# Altium schematic sheet toaster.SchDoc
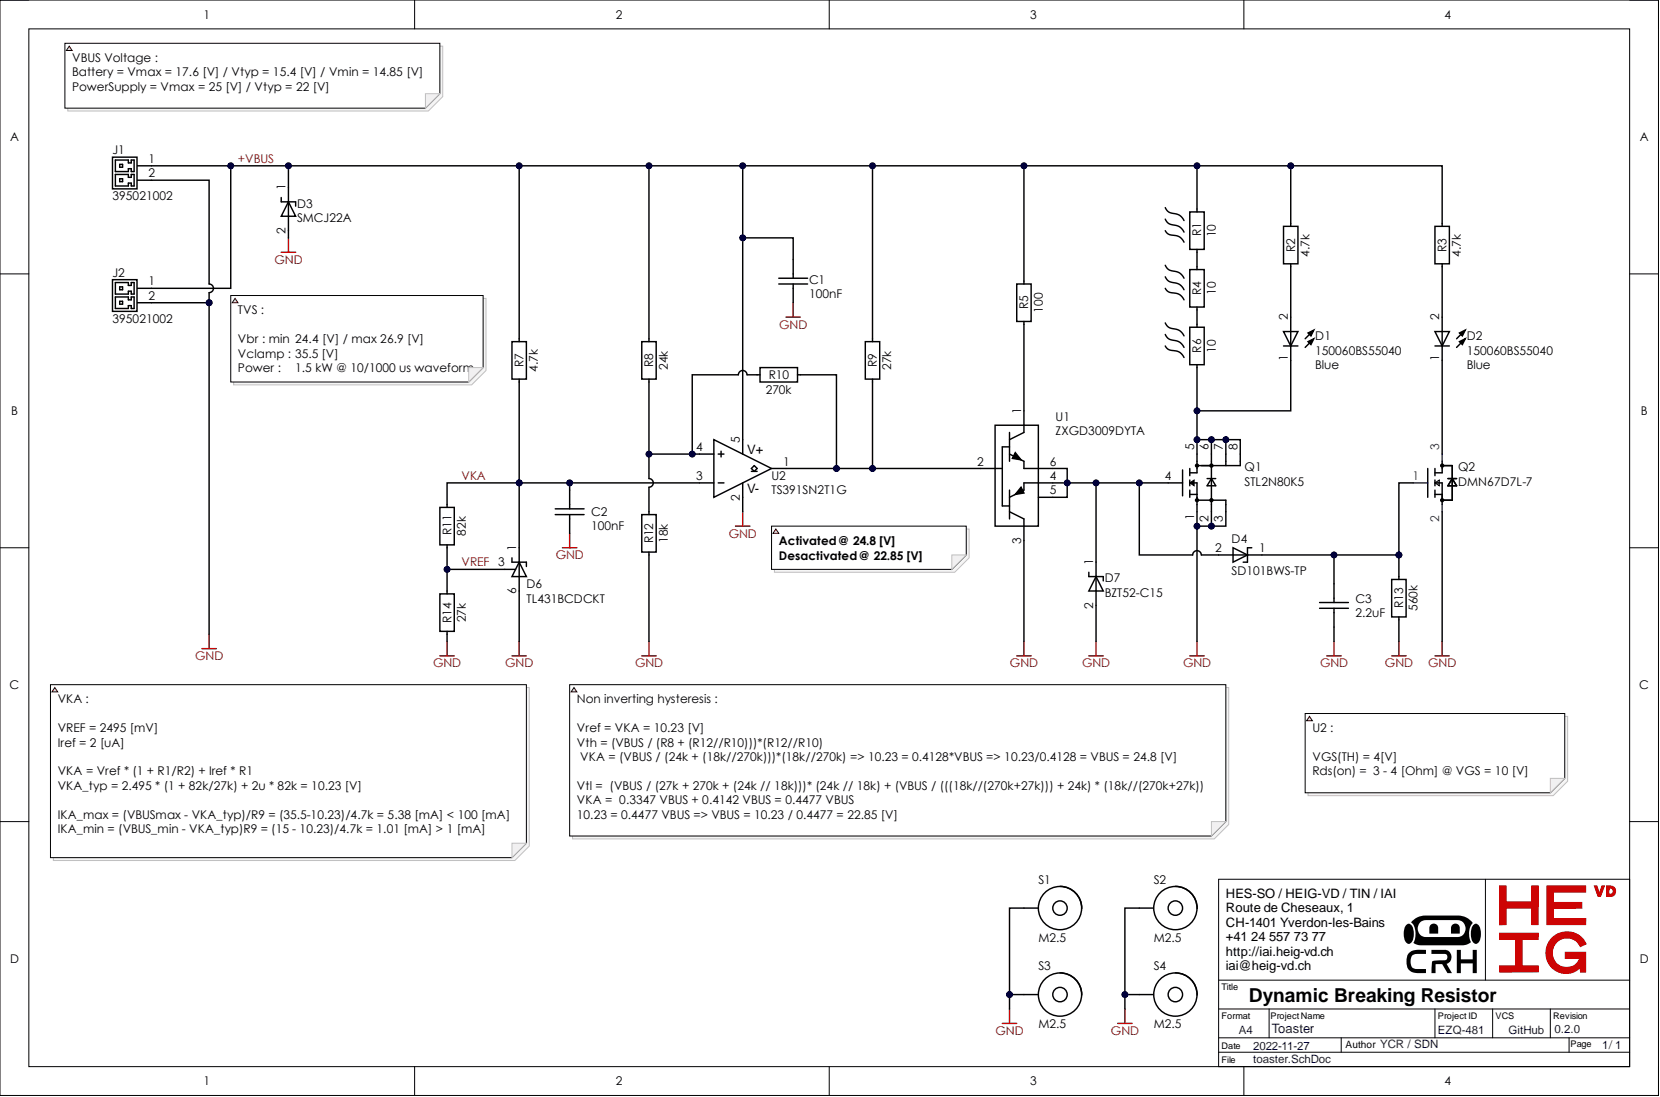
[diagram p0: toaster.SchDoc]

## Parts
C1  100nF  [CAPC1608X08N]
C2  100nF  [CAPC1608X08N]
C3  2.2uF  [CAPC1608X08N]
D1  150060BS55040  Color: Blue  [DIOC1608X05N]
D2  150060BS55040  Color: Blue  [DIOC1608X05N]
D3  SMCJ22A  [DIOM6959X26N]
D4  SD101BWS-TP  [SODFL260X115-2N]
D6  TL431BCDCKT  [SC70-6]
D7  BZT52-C15  [SODFL375X135-2N]
J1  395021002  [CONN2/PHOENIX/MC 1.5-2-G-3.5-BLACK]
J2  395021002  [CONN2/PHOENIX/MC 1.5-2-G-3.5-BLACK]
Q1  STL2N80K5  [PG-TDSON-8_INF]
Q2  DMN67D7L-7  [SOT23-3]
R1  10  [RW5S0FA]
R2  4.7k  [RESC1608X06N]
R3  4.7k  [RESC1608X06N]
R4  10  [RW5S0FA]
R5  100  [RESC1608X06N]
R6  10  [RW5S0FA]
R7  4.7k  [RESC1608X06N]
R8  24k  [RESC1608X06N]
R9  27k  [RESC1608X06N]
R10  270k  [RESC1608X06N]
R11  82k  [RESC1608X06N]
R12  18k  [RESC1608X06N]
R13  560k  [RESC1608X06N]
R14  27k  [RESC1608X06N]
S1  M2.5  [HW/HOLE/M2.5/VIA]  (no BOM)
S2  M2.5  [HW/HOLE/M2.5/VIA]  (no BOM)
S3  M2.5  [HW/HOLE/M2.5/VIA]  (no BOM)
S4  M2.5  [HW/HOLE/M2.5/VIA]  (no BOM)
U1  ZXGD3009DYTA  [SC70-6]
U2  TS391SN2T1G  [SOT23-5]

## Nets
GND: C1.2 C2.2 C3.2 D3.2 D6.6 D7.2 J1.2 J2.2 Q1.1 Q1.2 Q1.3 Q2.2 R12.1 R13.1 R14.1 S1.1 S2.1 S3.1 S4.1 U1.3 U2.2
+VBUS: C1.1 D3.1 J1.1 J2.1 R1.2 R2.2 R3.2 R5.2 R7.2 R8.2 R9.2 U2.5
VKA: C2.1 D6.1 R7.1 R11.2 U2.3
VREF: D6.3 R11.1 R14.2
NetC3_1: C3.1 D4.1 Q2.1 R13.2
NetD1_1: D1.1 Q1.5 Q1.6 Q1.7 Q1.8 R6.1
NetD1_2: D1.2 R2.1
NetD2_1: D2.1 Q2.3
NetD2_2: D2.2 R3.1
NetD4_2: D4.2 D7.1 Q1.4 U1.4 U1.5 U1.6
NetR1_1: R1.1 R4.2
NetR4_1: R4.1 R6.2
NetR5_1: R5.1 U1.1
NetR8_1: R8.1 R10.1 R12.2 U2.4
NetR9_1: R9.1 R10.2 U1.2 U2.1

## Library data of the parts
C1 C2 = CAP CER 0.1UF 50V X7R 0603: Chip Capacitor; Dielectric: X7R; Voltage: 50V; Component Kind: Standard; Supplier 1: Digi-Key; Supplier 2: Mouser; Supplier Part Number 1: 732-13187-1-ND; Supplier Part Number 2: 710-885382206004; Value: 100nF; Library Name: toaster.SchLib
C3 = CAP CER 2.2UF 50V X5R 0603: 2.2 µF ±10% 50V Ceramic Capacitor X5R 0603 (1608 Metric); Component Kind: Standard; Dielectric: X5R; Supplier 1: Digi-Key; Supplier 2: Mouser; Supplier Part Number 1: 490-12332-1-ND; Supplier Part Number 2: 81-GRT188R61H225KE3D; Value: 2.2uF; Voltage: 50V; Library Name: toaster.SchLib
D1 D2 = LED BLUE DIFFUSED 0603 SMD: Blue 470nm LED Indication - Discrete 3.2V 0603 (1608 Metric); Component Kind: Standard; Supplier 1: Digi-Key; Supplier 2: Mouser; Supplier Part Number 1: 732-12013-1-ND; Supplier Part Number 2: 710-150060BS55040; Value: 150060BS55040; Library Name: toaster.SchLib
D3 = TVS DIODE 22VWM 35.5VC DO214AB: 35,5V Pince 42,3 A Ipp TVS - Diodes Montage en surface DO-214AB (SMCJ); Component Kind: Standard; Supplier 1: Digi-Key; Supplier 2: Mouser; Supplier Part Number 1: SMCJ22ALFCT-ND; Supplier Part Number 2: 576-SMCJ22A; Value: SMCJ22A; Library Name: toaster.SchLib
D4 = DIODE SCHOTTKY 50V SOD323: Diode Schottky 50 V Surface Mount SOD-323; Component Kind: Standard; Supplier 1: Digi-Key; Supplier 2: Mouser; Supplier Part Number 1: SD101BWSTPMSCT-ND; Supplier Part Number 2: 833-SD101BWS-TP; Value: SD101BWS-TP; Library Name: toaster.SchLib
D6 = D ZENER ADJ: IC VREF SHUNT ADJ 0.5% SC70-6; Component Kind: Standard; Supplier 1: Digi-Key; Supplier 2: Mouser; Supplier Part Number 1: 296-TL431BCDCKTCT-ND; Supplier Part Number 2: 595-TL431BCDCKT; Value: TL431BCDCKT; Library Name: toaster.SchLib
D7 = Zener: Zener Diode 15 V 410 mW ±2% Surface Mount SOD-123; Component Kind: Standard; Supplier 1: Digi-Key; Supplier 2: Mouser; Supplier Part Number 1: 3757-BZT52-C15_R1_00001CT-ND; Supplier Part Number 2: 241-BZT52-C15R100001; Value: BZT52-C15; Library Name: toaster.SchLib
J1 J2 = TERM BLOCK HDR 2POS 90DEG 3.5MM: 2 Position Terminal Block Header, Male Pins, Shrouded (4 Side) 0.138" (3.50mm) 90°, Right Angle Through Hole; Imax: 8A; Component Kind: Standard; Supplier 1: Digi-Key; Supplier 2: Mouser; Supplier Part Number 1: WM7770-ND; Supplier Part Number 2: 538-39502-1002; Library Name: toaster.SchLib
Q1 = BSC0502NSIATMA1: MOSFET N-CH 800V 2A POWERFLATN-Channel 800 V 2A (Tc) 33W (Tc) Surface Mount PowerFlat™ (5x6); Component Kind: Standard; Supplier 1: Digi-Key; Supplier 2: Mouser; Supplier Part Number 1: 497-14539-1-ND; Supplier Part Number 2: 511-STL2N80K5; Value: STL2N80K5; Library Name: toaster.SchLib
Q2 = MOSFET N-CH 60V 210MA SOT23-3: N-Channel 60 V 210mA (Ta) 570mW (Ta) Surface Mount SOT-23-3; Component Kind: Standard; Supplier 1: Digi-Key; Supplier 2: Mouser; Supplier Part Number 1: DMN67D7L-7DICT-ND; Supplier Part Number 2: 621-DMN67D7L-7; Value: DMN67D7L-7; Library Name: toaster.SchLib
R1 R4 R6 = RES SMD 10 OHM 5% 5W L BEND: 10 Ohms ±5% 5W Chip Resistor SMD, L-Bend Wirewound; Component Kind: Standard; Simulation: RES; Supplier 1: Digi-Key; Supplier 2: Mouser; Supplier Part Number 1: RW5S0FA10R0JE-ND; Supplier Part Number 2: 588-RW5S0FA10R0JE; Value: 10; Library Name: toaster.SchLib
R2 R3 R7 = RES SMD 4.7K OHM 1% 1/10W 0603: 4.7 kOhms ±1% 0.1W, 1/10W Chip Resistor 0603 (1608 Metric) Automotive AEC-Q200, Moisture Resistant Thick Film; Component Kind: Standard; Simulation: RES; Supplier 1: Digi-Key; Supplier 2: Mouser; Supplier Part Number 1: YAG3613CT-ND; Supplier Part Number 2: 603-AC0603FR-074K7L; Value: 4.7k; Library Name: toaster.SchLib
R5 = RES SMD 100 OHM 1% 1/10W 0603: 100 Ohms ±1% 0.1W, 1/10W Chip Resistor 0603 (1608 Metric) Automotive AEC-Q200, Moisture Resistant Thick Film; Component Kind: Standard; Simulation: RES; Supplier 1: Digi-Key; Supplier 2: Mouser; Supplier Part Number 1: YAG3561CT-ND; Supplier Part Number 2: 603-AC0603FR-07100RL; Value: 100; Library Name: toaster.SchLib
R8 = RES SMD 24K OHM 1% 1/10W 0603: 24 kOhms ±1% 0.1W, 1/10W Chip Resistor 0603 (1608 Metric) Automotive AEC-Q200, Moisture Resistant Thick Film; Component Kind: Standard; Simulation: RES; Supplier 1: Digi-Key; Supplier 2: Mouser; Supplier Part Number 1: YAG3583CT-ND; Supplier Part Number 2: 603-AC0603FR-0724KL; Value: 24k; Library Name: toaster.SchLib
R9 R14 = RES SMD 27K OHM 1% 1/10W 0603: 82 kOhms ±1% 0.1W, 1/10W Chip Resistor 0603 (1608 Metric) Automotive AEC-Q200, Moisture Resistant Thick Film; Component Kind: Standard; Simulation: RES; Supplier 1: Digi-Key; Supplier 2: Mouser; Supplier Part Number 1: YAG3585CT-ND; Supplier Part Number 2: 603-AC0603FR-0727KL; Value: 27k; Library Name: toaster.SchLib
R10 = RES SMD 270K OHM 1% 1/10W 0603: 270 kOhms ±1% 0.1W, 1/10W Chip Resistor 0603 (1608 Metric) Automotive AEC-Q200, Moisture Resistant Thick Film; Component Kind: Standard; Simulation: RES; Supplier 1: Digi-Key; Supplier 2: Mouser; Supplier Part Number 1: YAG3584CT-ND; Supplier Part Number 2: 603-AC0603FR-07270KL; Value: 270k; Library Name: toaster.SchLib
R11 = RES SMD 82K OHM 1% 1/10W 0603: 82 kOhms ±1% 0.1W, 1/10W Chip Resistor 0603 (1608 Metric) Automotive AEC-Q200, Moisture Resistant Thick Film; Component Kind: Standard; Simulation: RES; Supplier 1: Digi-Key; Supplier 2: Mouser; Supplier Part Number 1: YAG3633CT-ND; Supplier Part Number 2: 603-AC0603FR-0782KL; Value: 82k; Library Name: toaster.SchLib
R12 = RES SMD 18K OHM 1% 1/10W 0603: 18 kOhms ±1% 0.1W, 1/10W Chip Resistor 0603 (1608 Metric) Automotive AEC-Q200, Moisture Resistant Thick Film; Component Kind: Standard; Simulation: RES; Supplier 1: Digi-Key; Supplier 2: Mouser; Supplier Part Number 1: YAG3570CT-ND; Supplier Part Number 2: 603-AC0603FR-0718KL; Value: 18k; Library Name: toaster.SchLib
R13 = RES SMD 560K OHM 1% 1/10W 0603: 560 kOhms ±1% 0.1W, 1/10W Chip Resistor 0603 (1608 Metric) Automotive AEC-Q200, Moisture Resistant Thick Film; Component Kind: Standard; Simulation: RES; Supplier 1: Digi-Key; Supplier 2: Mouser; Supplier Part Number 1: 311-560KLDCT-ND; Supplier Part Number 2: 603-AC0603FR-07560KL; Value: 560k; Library Name: toaster.SchLib
S1-S4 = HOLE/M2.5: Library Name: toaster.SchLib
U1 = IC GATE DRVR LOW-SIDE SOT363: Low-Side Gate Driver IC Non-Inverting SOT-363; Component Kind: Standard; Supplier 1: Digi-Key; Supplier 2: Mouser; Supplier Part Number 1: ZXGD3009DYTADICT-ND; Supplier Part Number 2: 621-ZXGD3009DYTA; Value: ZXGD3009DYTA; Library Name: toaster.SchLib
U2 = IC COMPARATOR LOW PWR 5-TSOP: Comparator General Purpose 5-TSOP; Component Kind: Standard; Supplier 1: Digi-Key; Supplier 2: Mouser; Supplier Part Number 1: TS391SN2T1GOSCT-ND; Supplier Part Number 2: 863-TS391SN2T1G; Value: TS391SN2T1G; Library Name: toaster.SchLib
